# Altium schematic sheet TPS65217DRSLR.SchDoc(TPS65217DRSLR)
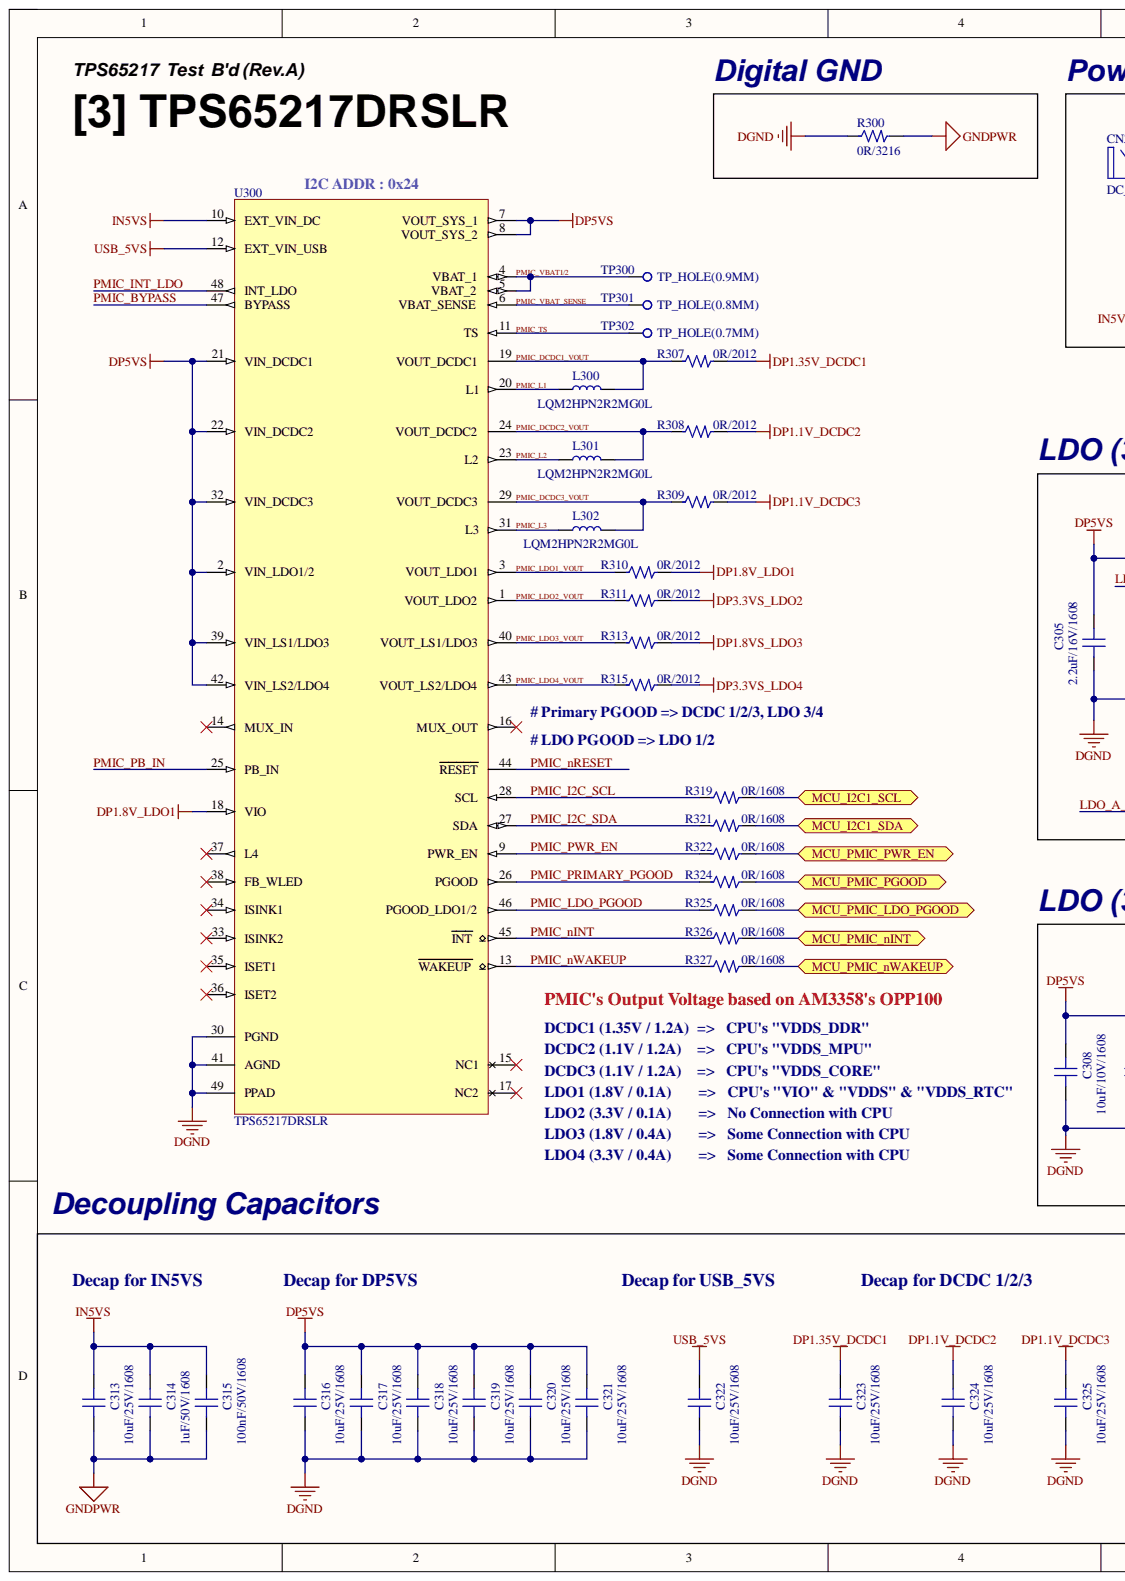
[diagram p0: TPS65217DRSLR.SchDoc, left]
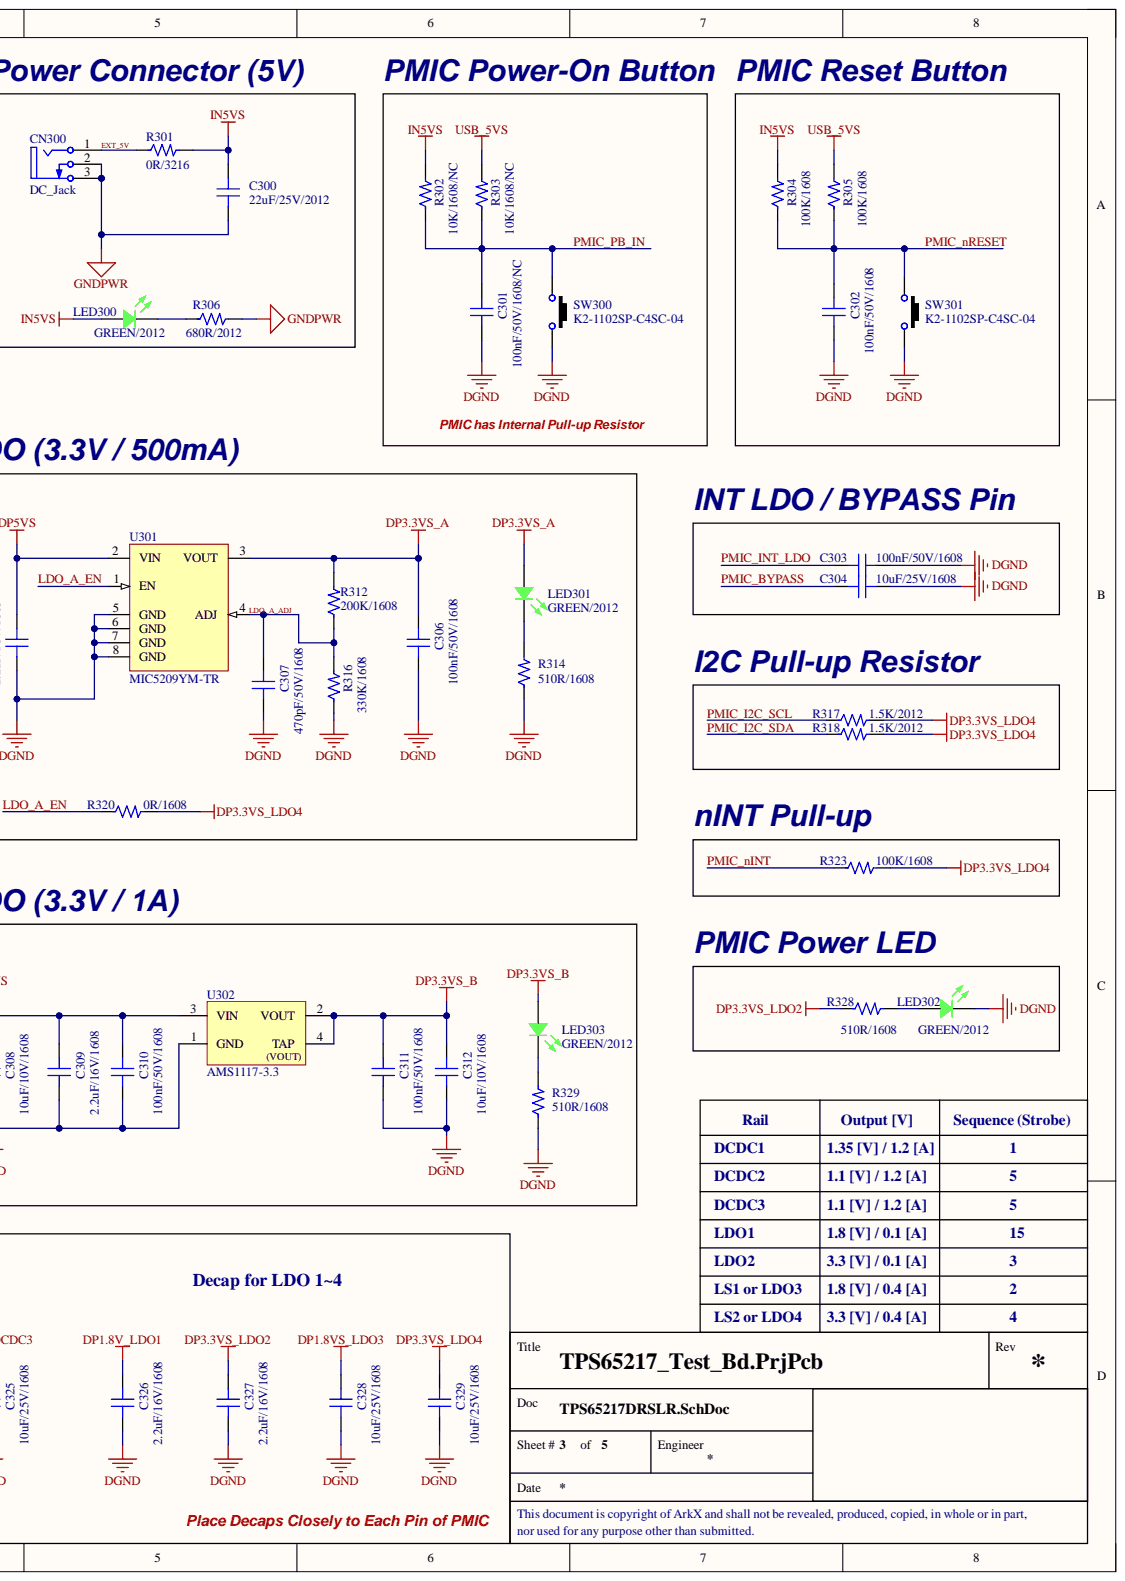
[diagram p1: TPS65217DRSLR.SchDoc, right]

## Parts
C300  22uF/25V/2012  [CAP 2012 (0805_INCH)]
C301  100nF/50V/1608/NC  [CAP 1608 (0603_INCH)/NC]
C302  100nF/50V/1608  [CAP 1608 (0603_INCH)]
C303  100nF/50V/1608  [CAP 1608 (0603_INCH)]
C304  10uF/25V/1608  [CAP 1608 (0603_INCH)]
C305  2.2uF/16V/1608  [CAP 1608 (0603_INCH)]
C306  100nF/50V/1608  [CAP 1608 (0603_INCH)]
C307  470pF/50V/1608  [CAP 1608 (0603_INCH)]
C308  10uF/10V/1608  [CAP 1608 (0603_INCH)]
C309  2.2uF/16V/1608  [CAP 1608 (0603_INCH)]
C310  100nF/50V/1608  [CAP 1608 (0603_INCH)]
C311  100nF/50V/1608  [CAP 1608 (0603_INCH)]
C312  10uF/10V/1608  [CAP 1608 (0603_INCH)]
C313  10uF/25V/1608  [CAP 1608 (0603_INCH)]
C314  1uF/50V/1608  [CAP 1608 (0603_INCH)]
C315  100nF/50V/1608  [CAP 1608 (0603_INCH)]
C316  10uF/25V/1608  [CAP 1608 (0603_INCH)]
C317  10uF/25V/1608  [CAP 1608 (0603_INCH)]
C318  10uF/25V/1608  [CAP 1608 (0603_INCH)]
C319  10uF/25V/1608  [CAP 1608 (0603_INCH)]
C320  10uF/25V/1608  [CAP 1608 (0603_INCH)]
C321  10uF/25V/1608  [CAP 1608 (0603_INCH)]
C322  10uF/25V/1608  [CAP 1608 (0603_INCH)]
C323  10uF/25V/1608  [CAP 1608 (0603_INCH)]
C324  10uF/25V/1608  [CAP 1608 (0603_INCH)]
C325  10uF/25V/1608  [CAP 1608 (0603_INCH)]
C326  2.2uF/16V/1608  [CAP 1608 (0603_INCH)]
C327  2.2uF/16V/1608  [CAP 1608 (0603_INCH)]
C328  10uF/25V/1608  [CAP 1608 (0603_INCH)]
C329  10uF/25V/1608  [CAP 1608 (0603_INCH)]
CN300  DC_Jack  [CON_DC-JACK]
L300  LQM2HPN2R2MG0L  [IND_LQM2HPN2R2MG0L]
L301  LQM2HPN2R2MG0L  [IND_LQM2HPN2R2MG0L]
L302  LQM2HPN2R2MG0L  [IND_LQM2HPN2R2MG0L]
LED300  GREEN/2012  [LED MHT170RGBCT (GREEN)]
LED301  GREEN/2012  [LED MHT170RGBCT (GREEN)]
LED302  GREEN/2012  [LED MHT170RGBCT (GREEN)]
LED303  GREEN/2012  [LED MHT170RGBCT (GREEN)]
R300  0R/3216  [RES 3216 (1206_inch)]
R301  0R/3216  [RES 3216 (1206_inch)]
R302  10K/1608/NC  [RES 1608 (0603_inch)/NC]
R303  10K/1608/NC  [RES 1608 (0603_inch)/NC]
R304  100K/1608  [RES 1608 (0603_inch)]
R305  100K/1608  [RES 1608 (0603_inch)]
R306  680R/2012  [RES 2012 (0805_inch)]
R307  0R/2012  [RES 2012 (0805_inch)]
R308  0R/2012  [RES 2012 (0805_inch)]
R309  0R/2012  [RES 2012 (0805_inch)]
R310  0R/2012  [RES 2012 (0805_inch)]
R311  0R/2012  [RES 2012 (0805_inch)]
R312  200K/1608  [RES 1608 (0603_inch)]
R313  0R/2012  [RES 2012 (0805_inch)]
R314  510R/1608  [RES 1608 (0603_inch)]
R315  0R/2012  [RES 2012 (0805_inch)]
R316  330K/1608  [RES 1608 (0603_inch)]
R317  1.5K/2012  [RES 2012 (0805_inch)]
R318  1.5K/2012  [RES 2012 (0805_inch)]
R319  0R/1608  [RES 1608 (0603_inch)]
R320  0R/1608  [RES 1608 (0603_inch)]
R321  0R/1608  [RES 1608 (0603_inch)]
R322  0R/1608  [RES 1608 (0603_inch)]
R323  100K/1608  [RES 1608 (0603_inch)]
R324  0R/1608  [RES 1608 (0603_inch)]
R325  0R/1608  [RES 1608 (0603_inch)]
R326  0R/1608  [RES 1608 (0603_inch)]
R327  0R/1608  [RES 1608 (0603_inch)]
R328  510R/1608  [RES 1608 (0603_inch)]
R329  510R/1608  [RES 1608 (0603_inch)]
SW300  K2-1102SP-C4SC-04  [SW K2-1102SP-C4SC-04]
SW301  K2-1102SP-C4SC-04  [SW K2-1102SP-C4SC-04]
TP300  TP_HOLE(0.9MM)  [TP_HOLE(0.9MM)]
TP301  TP_HOLE(0.8MM)  [TP_HOLE(0.8MM)]
TP302  TP_HOLE(0.7MM)  [TP_HOLE(0.7MM)]
U300  TPS65217DRSLR  [IC_VQFN-48(6x6)]
U301  MIC5209YM-TR  [IC_SOIC-8 (4.90x3.90mm)]
U302  AMS1117-3.3  [SOT 223-3 (DIODES INCORPORATED)]

## Ports
MCU_I2C1_SCL, MCU_I2C1_SDA, MCU_PMIC_LDO_PGOOD, MCU_PMIC_nINT, MCU_PMIC_nWAKEUP, MCU_PMIC_PGOOD, MCU_PMIC_PWR_EN

## Nets
DGND: C301.1 C302.1 C303.1 C304.1 C305.1 C306.1 C307.1 C308.1 C309.1 C310.1 C311.1 C312.1 C316.1 C317.1 C318.1 C319.1 C320.1 C321.1 C322.1 C323.1 C324.1 C325.1 C326.1 C327.1 C328.1 C329.1 LED302.2 R300.1 R314.1 R316.1 R329.1 SW300.3 SW301.3 U300.30(PGND) U300.41(AGND) U300.49(PPAD) U301.5(GND) U301.6(GND) U301.7(GND) U301.8(GND) U302.1(GND)
DP1.1V_DCDC2: C324.2 R308.2
DP1.1V_DCDC3: C325.2 R309.2
DP1.8V_LDO1: C326.2 R310.2 U300.18(VIO)
DP1.8VS_LDO3: C328.2 R313.2
DP1.35V_DCDC1: C323.2 R307.2
DP3.3VS_A: C306.2 LED301.4 R312.2 U301.3(VOUT)
DP3.3VS_B: C311.2 C312.2 LED303.4 U302.2(VOUT) U302.4(TAP)
DP3.3VS_LDO2: C327.2 R311.2 R328.1
DP3.3VS_LDO4: C329.2 R315.2 R317.2 R318.2 R320.2 R323.2
DP5VS: C305.2 C308.2 C309.2 C310.2 C316.2 C317.2 C318.2 C319.2 C320.2 C321.2 U300.2(VIN_LDO1/2) U300.7(VOUT_SYS_1) U300.8(VOUT_SYS_2) U300.21(VIN_DCDC1) U300.22(VIN_DCDC2) U300.32(VIN_DCDC3) U300.39(VIN_LS1/LDO3) U300.42(VIN_LS2/LDO4) U301.2(VIN) U302.3(VIN)
GNDPWR: C300.1 C313.1 C314.1 C315.1 CN300.2 CN300.3 R300.2 R306.2
IN5VS: C300.2 C313.2 C314.2 C315.2 LED300.4 R301.2 R302.2 R304.2 U300.10(EXT_VIN_DC)
USB_5VS: C322.2 R303.2 R305.2 U300.12(EXT_VIN_USB)
EXT_5V: CN300.1 R301.1
LDO_A_ADJ: C307.2 R312.1 R316.2 U301.4(ADJ)
LDO_A_EN: R320.1 U301.1(EN)
MCU_I2C1_SCL: R319.2 ; port MCU_I2C1_SCL
MCU_I2C1_SDA: R321.2 ; port MCU_I2C1_SDA
MCU_PMIC_LDO_PGOOD: R325.2 ; port MCU_PMIC_LDO_PGOOD
MCU_PMIC_nINT: R326.2 ; port MCU_PMIC_nINT
MCU_PMIC_nWAKEUP: R327.2 ; port MCU_PMIC_nWAKEUP
MCU_PMIC_PGOOD: R324.2 ; port MCU_PMIC_PGOOD
MCU_PMIC_PWR_EN: R322.2 ; port MCU_PMIC_PWR_EN
PMIC_BYPASS: C304.2 U300.47(BYPASS)
PMIC_DCDC1_VOUT: L300.2 R307.1 U300.19(VOUT_DCDC1)
PMIC_DCDC2_VOUT: L301.2 R308.1 U300.24(VOUT_DCDC2)
PMIC_DCDC3_VOUT: L302.2 R309.1 U300.29(VOUT_DCDC3)
PMIC_I2C_SCL: R317.1 R319.1 U300.28(SCL)
PMIC_I2C_SDA: R318.1 R321.1 U300.27(SDA)
PMIC_INT_LDO: C303.2 U300.48(INT_LDO)
PMIC_L1: L300.1 U300.20(L1)
PMIC_L2: L301.1 U300.23(L2)
PMIC_L3: L302.1 U300.31(L3)
PMIC_LDO1_VOUT: R310.1 U300.3(VOUT_LDO1)
PMIC_LDO2_VOUT: R311.1 U300.1(VOUT_LDO2)
PMIC_LDO3_VOUT: R313.1 U300.40(VOUT_LS1/LDO3)
PMIC_LDO4_VOUT: R315.1 U300.43(VOUT_LS2/LDO4)
PMIC_LDO_PGOOD: R325.1 U300.46(PGOOD_LDO1/2)
PMIC_nINT: R323.1 R326.1 U300.45(INT)
PMIC_nRESET: C302.2 R304.1 R305.1 SW301.1 U300.44(RESET)
PMIC_nWAKEUP: R327.1 U300.13(WAKEUP)
PMIC_PB_IN: C301.2 R302.1 R303.1 SW300.1 U300.25(PB_IN)
PMIC_PRIMARY_PGOOD: R324.1 U300.26(PGOOD)
PMIC_PWR_EN: R322.1 U300.9(PWR_EN)
PMIC_TS: TP302.1 U300.11(TS)
PMIC_VBAT1/2: TP300.1 U300.4(VBAT_1) U300.5(VBAT_2)
PMIC_VBAT_SENSE: TP301.1 U300.6(VBAT_SENSE)
NetLED300_2: LED300.2 R306.1
NetLED301_2: LED301.2 R314.2
NetLED302_4: LED302.4 R328.2
NetLED303_2: LED303.2 R329.2

Not connected: U300 14(MUX_IN) 15(NC1) 16(MUX_OUT) 17(NC2) 33(ISINK2) 34(ISINK1) 35(ISET1) 36(ISET2) 37(L4) 38(FB_WLED)

## Library data of the parts
C300 = CAP_22uF/25V/2012: Ceramic CAP_22uF/25V/2012; Component Kind: Standard; JLCPCB Part Type: Basic Part; LCSC Part #: C45783; Library Name: Arkx.IntLib
C301 = CAP_NC_100nF/50V/1608: Ceramic CAP_100nF/50V/1608; Component Kind: Standard; Library Name: Arkx.IntLib
C302 C303 C306 C310 C311 C315 = CAP_100nF/50V/1608: Ceramic CAP_100nF/50V/1608; Component Kind: Standard; JLCPCB Part Type: Basic Part; LCSC Part #: C14663; Library Name: Arkx.IntLib
C304 C313 C316-C325 C328 C329 = CAP_10uF/25V/1608: Ceramic CAP_10uF/25V/1608; Component Kind: Standard; JLCPCB Part Type: Basic Part; LCSC Part #: C96446; Library Name: Arkx.IntLib
C305 C309 C326 C327 = CAP_2.2uF/16V/1608: Ceramic CAP_2.2uF/16V/1608; Component Kind: Standard; JLCPCB Part Type: Basic Part; LCSC Part #: C23630; Library Name: Arkx.IntLib
C307 = CAP_470pF/50V/1608: Ceramic CAP_470pF/50V/1608; Component Kind: Standard; JLCPCB Part Type: Basic Part; LCSC Part #: C1620; Library Name: Arkx.IntLib
C308 C312 = CAP_10uF/10V/1608: Ceramic CAP_10uF/10V/1608; Component Kind: Standard; JLCPCB Part Type: Basic Part; LCSC Part #: C19702; Library Name: Arkx.IntLib
C314 = CAP_1uF/50V/1608: Ceramic CAP_1uF/50V/1608; Component Kind: Standard; JLCPCB Part Type: Basic Part; LCSC Part #: C15849; Library Name: Arkx.IntLib
CN300 = CON_DC-Jack: Component Kind: Standard; ComponentLink1Description: Manufacturer Link; ComponentLink1URL: http://www.cliffuk.co.uk/; Description: DC_Jack; Library Name: Arkx.IntLib
L300-L302 = IND_LQM2HPN2R2MG0L: 2.2 µH Shielded Multilayer Inductor 1.3 A 100mOhm Max 1008 (2520 Metric); Component Kind: Standard; JLCPCB Part Type: Extended Part; LCSC Part #: C86092; Library Name: Arkx.IntLib
LED300-LED303 = LED_MHT170RGBCT(GREEN): Colorless transparence -30℃~+85℃ Positive post Common anode RGB 120° 0805  Light Emitting Diodes (LED) ROHS; Component Kind: Standard; JLCPCB Part Type: Extended Part; LCSC Part #: C2962094; Library Name: Arkx.IntLib
R300 R301 = RES_0R/3216/0.25W: Power Rating: 0.1W; Precision: 1%; Component Kind: Standard; Description: RES_0R/3216/0.25W; JLCPCB Part Type: Basic Part; LCSC Part #: C17888; Size: 1608; Library Name: Arkx.IntLib
R302 R303 = RES_NC_10K/1608/0.1W: RES_10K/1608/0.1W; Power Rating: 0.1W; Precision: 1%; Value: 10K; Component Kind: Standard; Size: 1608; Library Name: Arkx.IntLib
R304 R305 R323 = RES_100K/1608/0.1W: Power Rating: 0.1W; Precision: 1%; Value: 100K; Component Kind: Standard; Description: RES_100K/1608/0.1W; JLCPCB Part Type: Basic Part; LCSC Part #: C25803; Size: 1608; Library Name: Arkx.IntLib
R306 = RES_680R/2012/0.125W: Power Rating: 0.125W; Precision: 1%; Value: 680R; Component Kind: Standard; Description: RES_680R/2012/0.125W; JLCPCB Part Type: Basic Part; LCSC Part #: C17798; Size: 2012; Library Name: Arkx.IntLib
R307-R311 R313 R315 = RES_0R/2012/0.125W: Power Rating: 0.125W; Precision: 1%; Value: 0R; Component Kind: Standard; Description: RES_0R/2012/0.125W; JLCPCB Part Type: Basic Part; LCSC Part #: C17477; Size: 2012; Library Name: Arkx.IntLib
R312 = RES_200K/1608/0.1W: Power Rating: 0.1W; Precision: 1%; Value: 200K; Component Kind: Standard; Description: RES_200K/1608/0.1W; JLCPCB Part Type: Basic Part; LCSC Part #: C25811; Size: 1608; Library Name: Arkx.IntLib
R314 R328 R329 = RES_510R/1608/0.1W: Power Rating: 0.1W; Precision: 1%; Value: 510R; Component Kind: Standard; Description: RES_510R/1608/0.1W; JLCPCB Part Type: Basic Part; LCSC Part #: C23193; Size: 1608; Library Name: Arkx.IntLib
R316 = RES_330K/1608/0.1W: Power Rating: 0.1W; Precision: 1%; Value: 330K; Component Kind: Standard; Description: RES_330K/1608/0.1W; JLCPCB Part Type: Basic Part; LCSC Part #: C23137; Size: 1608; Library Name: Arkx.IntLib
R317 R318 = RES_1.5K/2012/0.125W: Power Rating: 0.125W; Precision: 1%; Value: 1.5K; Component Kind: Standard; Description: RES_1.5K/2012/0.125W; JLCPCB Part Type: Basic Part; LCSC Part #: C4310; Size: 2012; Library Name: Arkx.IntLib
R319-R322 R324-R327 = RES_0R/1608/0.1W: Power Rating: 0.1W; Precision: 1%; Value: 0R; Component Kind: Standard; Description: RES_0R/1608/0.1W; JLCPCB Part Type: Basic Part; LCSC Part #: C21189; Size: 1608; Library Name: Arkx.IntLib
SW300 SW301 = SW_K2-1102SP-C4SC-04(2P): -25℃~+85℃ 6mm 5mm Round Button 50mA Brick nogging 6mm SPST 100MΩ 100000 250gf@±30gf 12V SMD  Tactile Switches ROHS; Component Kind: Standard; JLCPCB Part Type: Extended Part; LCSC Part #: C127509; Library Name: Arkx.IntLib
U300 = IC_PMIC_TPS65217DRSLR: Battery Management, Display (LED Drivers), Handheld/Mobile Devices, Power Supply PMIC 48-VQFN (6x6); Component Kind: Standard; ComponentLink1Description: Datasheet; ComponentLink1URL: https://www.mouser.kr/datasheet/2/609/8023fj-2488599.pdf; JLCPCB Part Type: Extended Part; LCSC Part #: C702315; Library Name: Arkx.IntLib
U301 = IC_REG_MIC5209YM-TR: Linear Voltage Regulator IC Positive Adjustable 1 Output 500mA 8-SOIC; Component Kind: Standard; JLCPCB Part Type: Extended Part; LCSC Part #: C461593; Library Name: Arkx.IntLib
U302 = IC_REG_AMS1117-3.3: 72dB@(120Hz) 1A 1.3V@(800mA) Fixed 3.3V~3.3V Positive 1 SOT-223  Linear Voltage Regulators (LDO) ROHS; Component Kind: Standard; JLCPCB Part Type: Basic Part; LCSC Part #: C6186; Library Name: Arkx.IntLib
TP300 = TP_HOLE(0.9MM): Component Kind: Standard; Description: TP_HOLE(0.9MM); Library Name: Arkx.IntLib
TP301 = TP_HOLE(0.8MM): Component Kind: Standard; Description: TP_HOLE(0.8MM); Library Name: Arkx.IntLib
TP302 = TP_HOLE(0.7MM): Component Kind: Standard; Description: TP_HOLE(0.7MM); Library Name: Arkx.IntLib
